# Altium schematic sheet Voltage_Converter.SchDoc
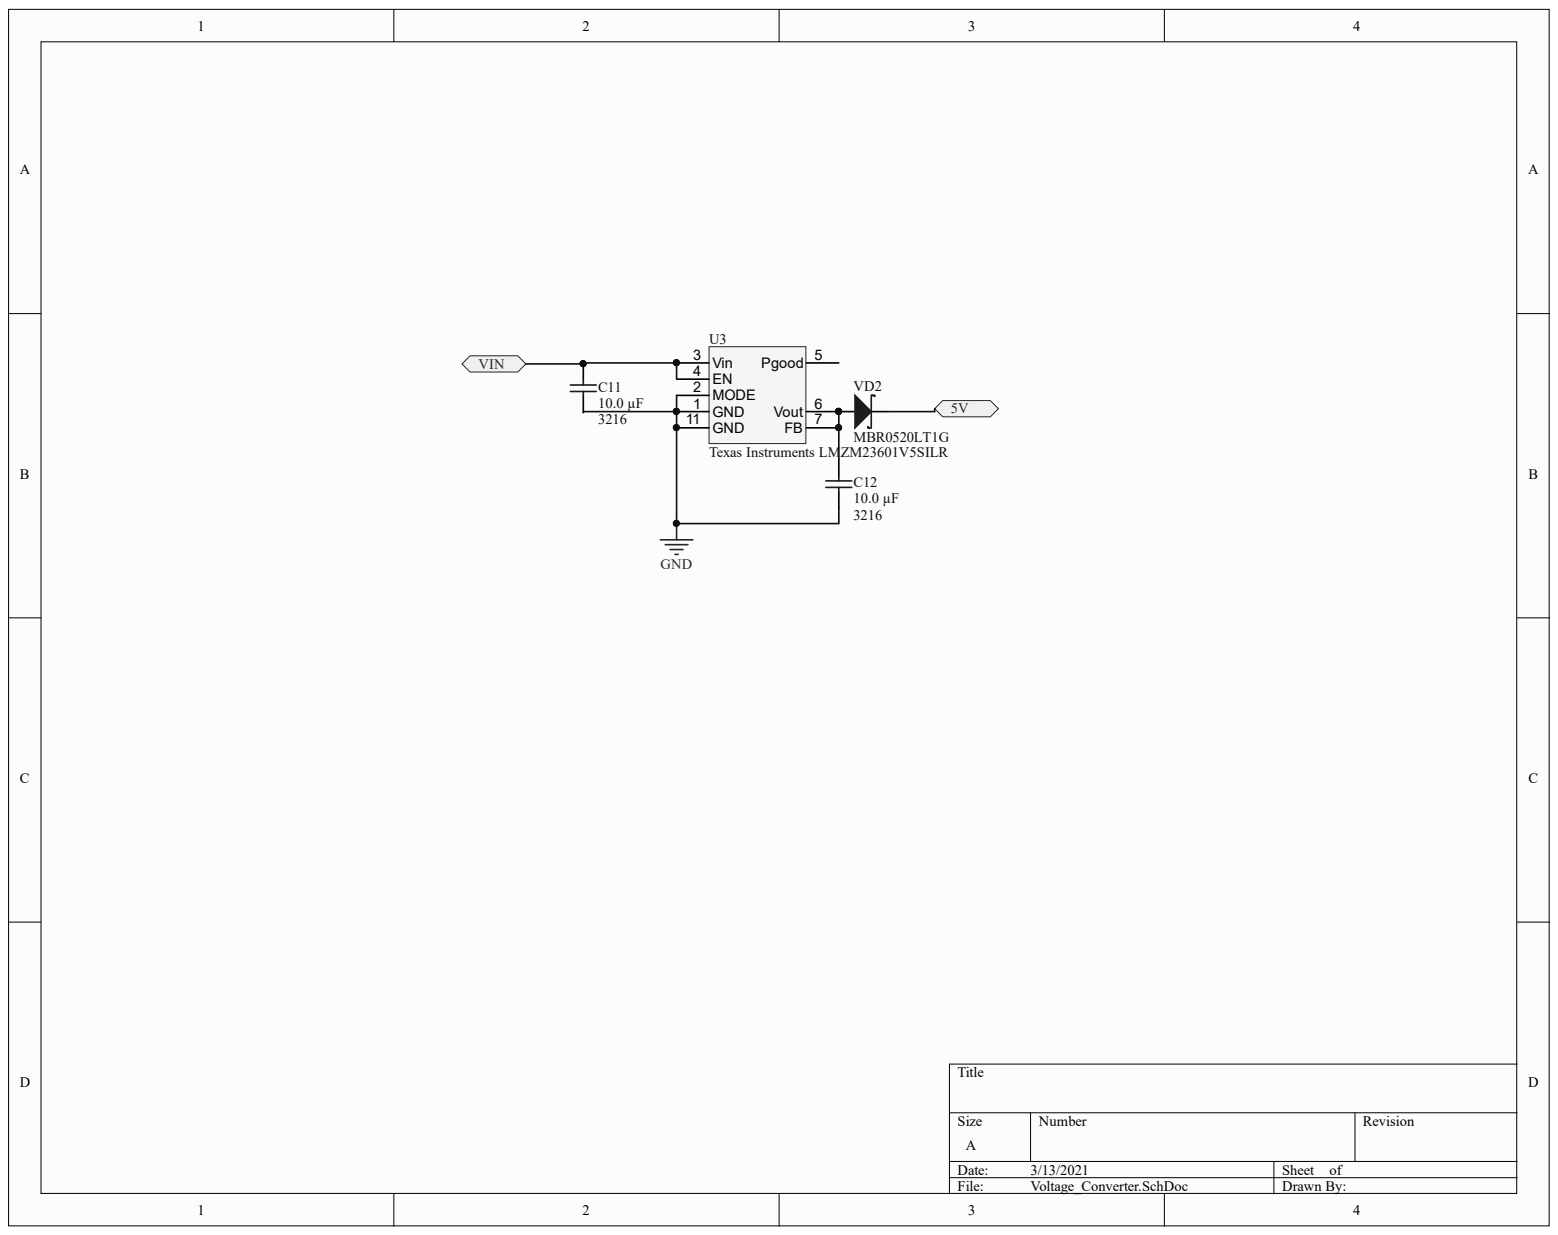
[diagram p0: Voltage_Converter.SchDoc]

## Parts
C11  Size: 3216  [High Density]  (hidden comment: Cap)
C12  Size: 3216  [High Density]  (hidden comment: Cap)
U3  Texas Instruments LMZM23601V5SILR  [PCB-hwh8xdcdeu6867capd15-1]
VD2  MBR0520LT1G  [SOD-123_B]

## Ports
5V, VIN

## Nets
GND: C11.2 C12.2 U3.1(GND) U3.2(MODE) U3.11(GND)
5V: VD2.1 ; port 5V
VIN: C11.1 U3.3(Vin) U3.4(EN) ; port VIN
NetC12_1: C12.1 U3.6(Vout) U3.7(FB) VD2.2

Not connected: U3 5(Pgood)

## Library data of the parts
C11 C12 = CMP-bac072e4006c6507-6: CL31 Series 10 uF 50 V ±10 % Tolerance X5R SMT Multilayer Ceramic Capacitor; Manufacturer Part Number: CL31A106KBHNNNE; Capacitance: 10.0 µF; Lead-Free Status: Lead Free; Voltage Rating (DC): 50.0 V; Component Kind: Standard; Library Name: CommunityVault; Mounting Style: Surface Mount; OctopartID: bac072e4006c6507
U3 = CMP-a7776b3d5a0878b0-5: 36-V, 1-A Step-Down DC-DC Power Module in 3.8-mm × 3-mm Package 10-uSiP -40 to 125; Component Kind: Standard; Library Name: CommunityVault
VD2 = CMP-3786467-11: Rectifier, Schottky Power; Plastic Sod-123; 0.5 a @ +90c If; +125; 20v; 1000; Current Rating: 0.5 A; Forward Voltage: 0.385 V; Lead-Free Status: Lead Free; Polarity: Standard; Voltage Rating (DC): 20.0 V; Case/Package: SOD-123; Component Kind: Standard; Library Name: CommunityVault; Lifecycle Status: Active; Manufacturer Part Number: MBR0520LT1G; Mounting Style: Surface Mount; Number of Pins: 2; OctopartID: 81934899af805e54; Octopart Url: http://octopart.com/mbr0520lt1g-on+semiconductor-2933; REACH SVHC Compliance: No SVHC; RoHS: Compliant; Seller Landing  Url: https://octopart-clicks.com/click/track?country=US&ak=8157f166&sig=02b8313&sid=5489&ppid=2933&vpid=120602749&ct=offers
